# Altium schematic sheet Page-1.SchDoc
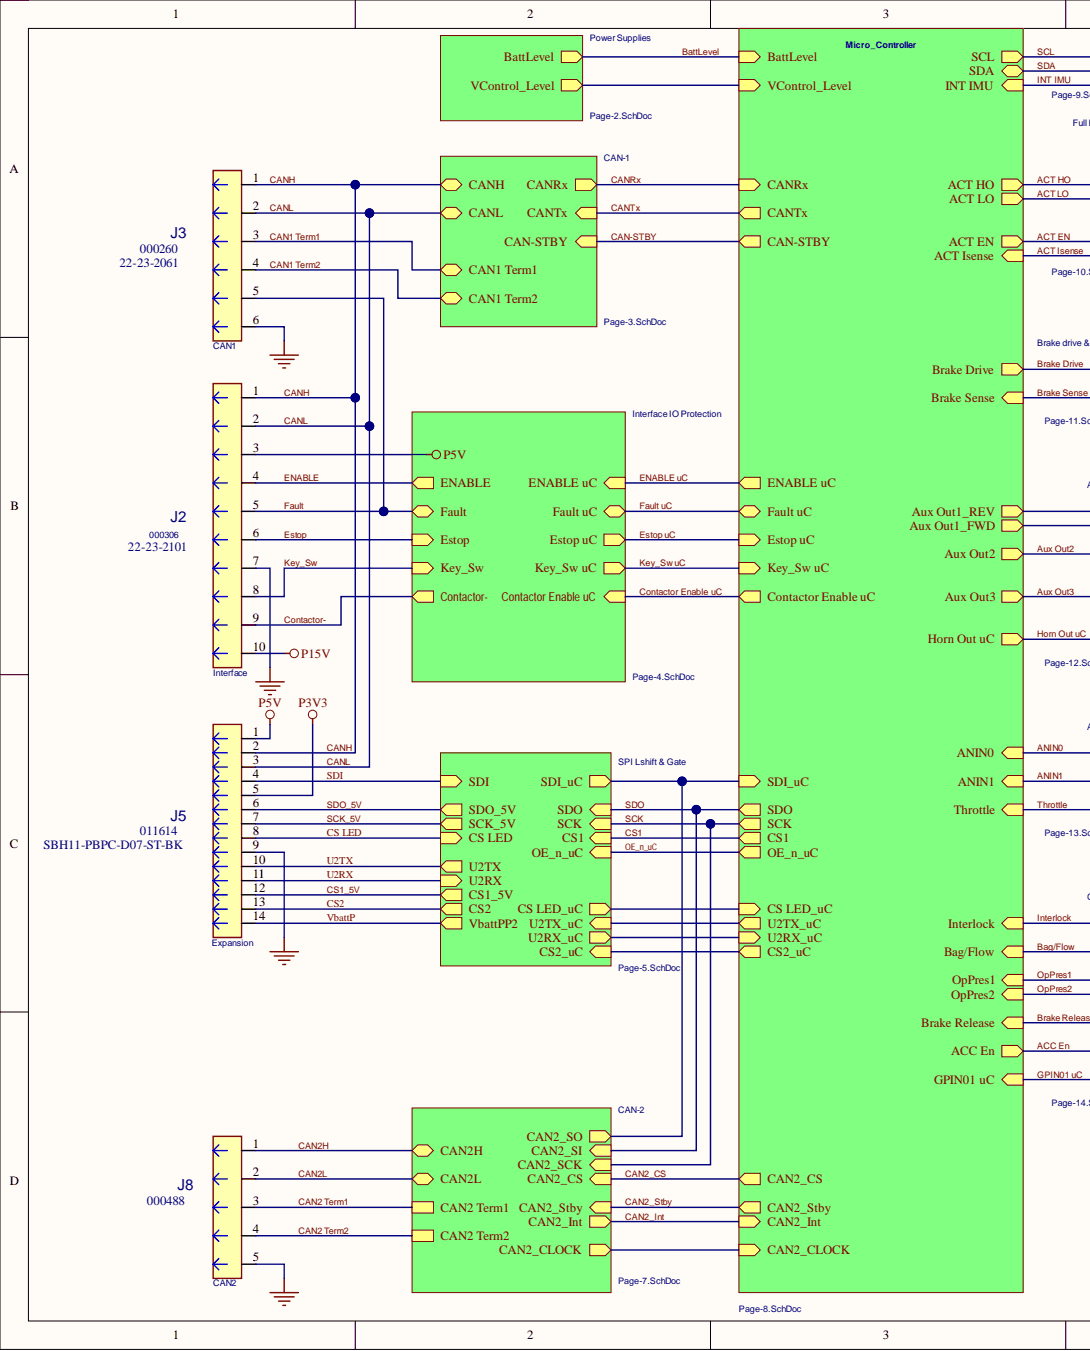
[diagram p0: Page-1.SchDoc, left]
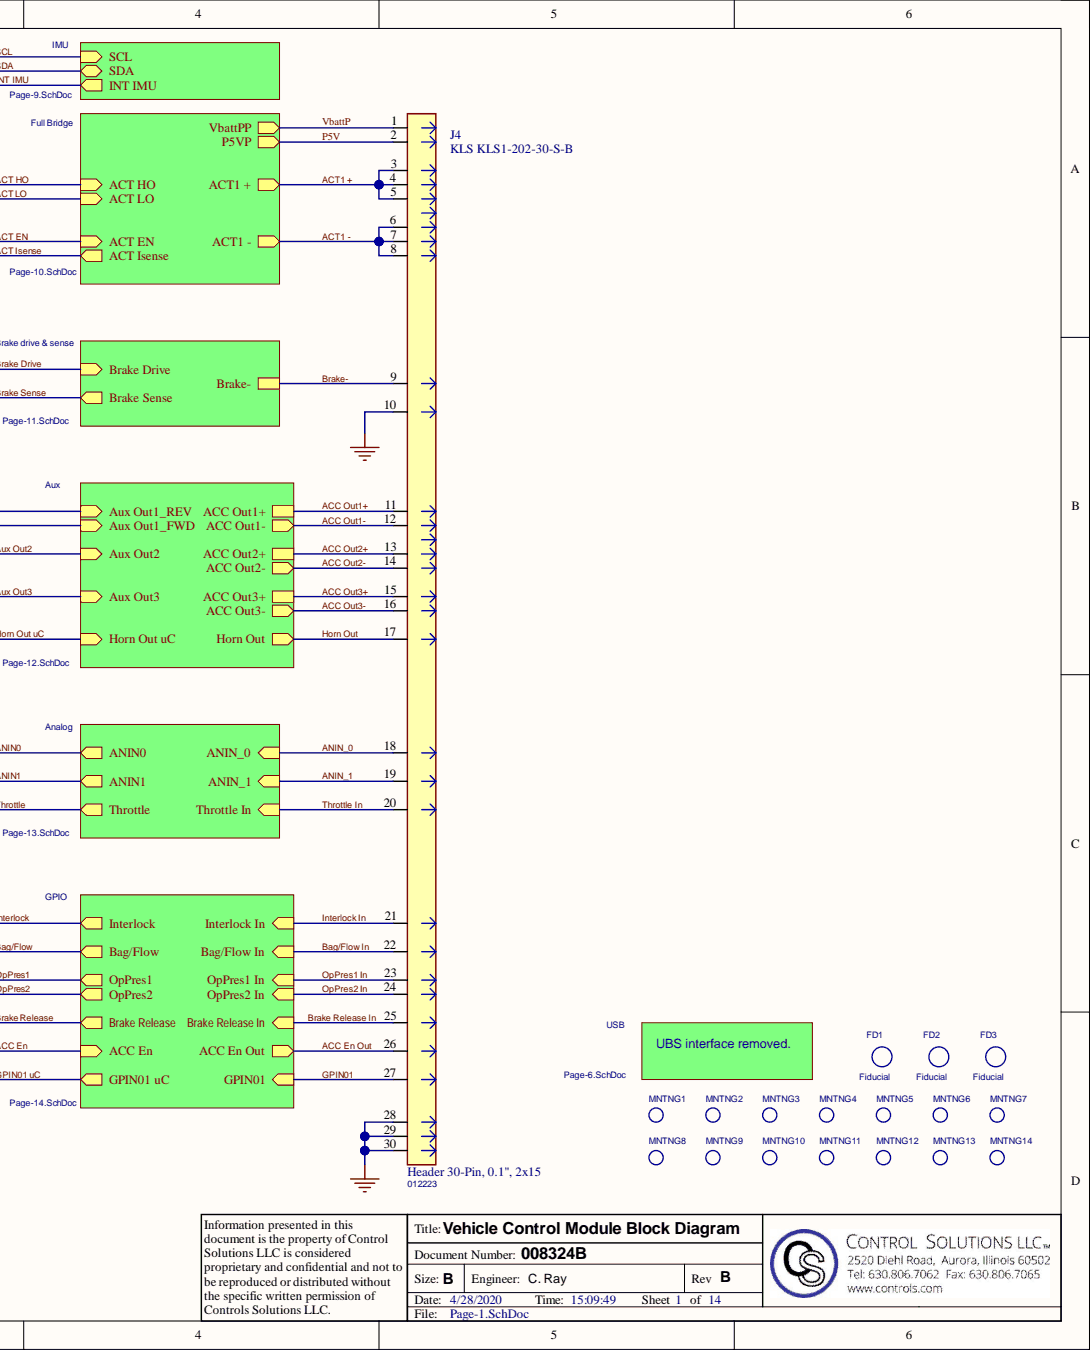
[diagram p1: Page-1.SchDoc, right]

## Parts
FD1  Fiducial  [CSISM/FIDUCIAL_TOP&BOTTOM]
FD2  Fiducial  [CSISM/FIDUCIAL_TOP&BOTTOM]
FD3  Fiducial  [CSISM/FIDUCIAL_TOP&BOTTOM]
J2  Interface  Mfr. P/N: 22-23-2101  [CSIHEAD10\100\LOCK_10-1_PIN1IND]
J3  CAN1  [CSIHEAD6\100\LOCK_6-1_PIN1IND]
J4  Header 30-Pin, 0.1", 2x15  Manufacturer: KLS  Part Number: 012223  [Straight 30 Pin TH Connector, 0.1", 2x15]
J5  Expansion  Distr. P/N: SBH11-PBPC-D07-ST-BK  [CS/HEADER/100/2X7_SHROUD_PIN1IND]
J8  CAN2  Part Number: 000488  [CSIHEAD5\100\LOCK_5-1_PIN1IND]
MNTNG1  M HOLE1_1  [MTHOLE_156]  (value not drawn)
MNTNG2  M HOLE1_1  [MTHOLE_156]  (value not drawn)
MNTNG3  M HOLE1_1  [MTHOLE_156]  (value not drawn)
MNTNG4  M HOLE1_1  [MTHOLE_156]  (value not drawn)
MNTNG5  M HOLE1_1  [MTHOLE_156]  (value not drawn)
MNTNG6  M HOLE1_1  [MTHOLE_156]  (value not drawn)
MNTNG7  M HOLE1_1  [MTHOLE_156]  (value not drawn)
MNTNG8  M HOLE1_1  [MTHOLE_156]  (value not drawn)
MNTNG9  M HOLE1_1  [MTHOLE_156]  (value not drawn)
MNTNG10  M HOLE1_1  [MTHOLE_156]  (value not drawn)
MNTNG11  M HOLE1_1  [MTHOLE_156]  (value not drawn)
MNTNG12  M HOLE1_1  [MTHOLE_156]  (value not drawn)
MNTNG13  M HOLE1_1  [MTHOLE_156]  (value not drawn)
MNTNG14  M HOLE1_1  [MTHOLE_156]  (value not drawn)

## Nets
GND: J2.7 J3.6 J4.10 J4.28 J4.29 J4.30 J5.9 J8.5
P3V3: J5.5
P5V: J2.3 J5.1
P15V: J2.10
ACC En Out: J4.26
ACC Out1+: J4.11
ACC Out1-: J4.12
ACC Out2+: J4.13
ACC Out2-: J4.14
ACC Out3+: J4.15
ACC Out3-: J4.16
ACT1 +: J4.3 J4.4 J4.5
ACT1 -: J4.6 J4.7 J4.8
ANIN_0: J4.18
ANIN_1: J4.19
Bag/Flow In: J4.22
Brake Release In: J4.25
Brake-: J4.9
CAN1 Term1: J3.3
CAN1 Term2: J3.4
CAN2 Term1: J8.3
CAN2 Term2: J8.4
CAN2H: J8.1
CAN2L: J8.2
CANH: J2.1 J3.1 J5.2
CANL: J2.2 J3.2 J5.3
Contactor-: J2.9
CS1_5V: J5.12
CS2: J5.13
CS LED: J5.8
ENABLE: J2.4
Estop: J2.6
Fault: J2.5 J3.5
GPIN01: J4.27
Horn Out: J4.17
Interlock In: J4.21
Key_Sw: J2.8
OpPres1 In: J4.23
OpPres2 In: J4.24
SCK_5V: J5.7
SDI: J5.4
SDO_5V: J5.6
Throttle In: J4.20
U2RX: J5.11
U2TX: J5.10
NetFD1_1: FD1.1
NetFD2_1: FD2.1
NetFD3_1: FD3.1
NetJ4_1: J4.1
NetJ4_2: J4.2
NetJ5_14: J5.14
NetMNTNG1_1: MNTNG1.1
NetMNTNG2_1: MNTNG2.1
NetMNTNG3_1: MNTNG3.1
NetMNTNG4_1: MNTNG4.1
NetMNTNG5_1: MNTNG5.1
NetMNTNG6_1: MNTNG6.1
NetMNTNG7_1: MNTNG7.1
NetMNTNG8_1: MNTNG8.1
NetMNTNG9_1: MNTNG9.1
NetMNTNG10_1: MNTNG10.1
NetMNTNG11_1: MNTNG11.1
NetMNTNG12_1: MNTNG12.1
NetMNTNG13_1: MNTNG13.1
NetMNTNG14_1: MNTNG14.1
ACC En:
ACT EN:
ACT HO:
ACT Isense:
ACT LO:
ANIN0:
ANIN1:
Aux Out1_FWD:
Aux Out1_REV:
Aux Out2:
Aux Out3:
Bag/Flow:
BattLevel:
Brake Drive:
Brake Release:
Brake Sense:
CAN2_CLOCK:
CAN2_CS:
CAN2_Int:
CAN2_SO:
CAN2_Stby:
CAN-STBY:
CANRx:
CANTx:
Contactor Enable uC:
CS1:
CS2_uC:
CS LED_uC:
ENABLE uC:
Estop uC:
Fault uC:
GPIN01 uC:
Horn Out uC:
INT IMU:
Interlock:
Key_Sw uC:
OE_n_uC:
OpPres1:
OpPres2:
SCK:
SCL:
SDA:
SDO:
Throttle:
U2RX_uC:
U2TX_uC:
VControl_Level:

## Library data of the parts
FD1 = Fiducial_1: COMPFIXED: YES; COMPGROUP: 0; COMPHEIGHT: 0.000; COMPKEY: NO; COMPLOC: [5050.000,225.000]; COMPSIDE: TOP; FPLIST: CSISM/FIDUCIAL; NC: 1; PSpiceOnly: False; Variant-1: Don't populate; COMPLOCKED: YES; Component Kind: Standard; COMPROT: 0; DECOUPLER: NO; DigiKey LargeQtyCost: 0; MEMORY: NO; Note1: Note1; Note2: Note2; Note3: Note3; Part Number: N/A; Status: N/A; TCR: N/A
FD2 = Fiducial_1: COMPFIXED: YES; COMPGROUP: 0; COMPHEIGHT: 0.000; COMPKEY: NO; COMPLOC: [450.000,225.000]; COMPSIDE: TOP; FPLIST: CSISM/FIDUCIAL_B,CSISM/FIDUCIAL; NC: 1; PSpiceOnly: False; Variant-1: Don't populate; COMPLOCKED: YES; Component Kind: Standard; COMPROT: 0; DECOUPLER: NO; DigiKey LargeQtyCost: 0; MEMORY: NO; Note1: Note1; Note2: Note2; Note3: Note3; Part Number: N/A; TCR: N/A
FD3 = Fiducial_1: Part Number: Not Pop.; COMPFIXED: YES; COMPGROUP: 0; COMPHEIGHT: 0.000; COMPKEY: NO; COMPLOC: [450.000,7875.000]; COMPSIDE: TOP; FPLIST: CSISM/FIDUCIAL_TOP&BOTTOM,CSISM/FIDUCIAL; NC: 1; PSpiceOnly: False; Variant-1: Don't populate; COMPLOCKED: YES; Component Kind: Standard; COMPROT: 0; DECOUPLER: NO; DigiKey LargeQtyCost: 0; MEMORY: NO; Note1: Note1; Note2: Note2; Note3: Note3; TCR: N/A
J2 = header 10: CONN HEADER VERT 10POS 2.54MM; Manufacturer: Molex; Part Number: 000306; COMPFIXED: YES; COMPGROUP: 0; COMPHEIGHT: 0.000; COMPKEY: NO; COMPLOC: [5250.000,1950.000]; COMPROT: 90; COMPSIDE: TOP; CURRENT: 4.0A; Digi 100 qty: 0.44760; Distr. P/N: WM4208-ND; FPLIST: CSIHEAD10\100\LOCK_10-1_PIN1IND_REV1,CSIHEAD10\100\LOCK_10-1,CSIHEAD10\100\LOCK_10-1_REV1,CSIHEAD10\100\LOCK_10-1_PIN1IND; Voltage: 500V; COMPLOCKED: YES; Component Kind: Standard; Datasheet: https://www.molex.com/pdm_docs/sd/022232101_sd.pdf; DECOUPLER: NO; DigiKey P/N, (CT): WM4208-ND; Flammability: 94V-0; MEMORY: NO; Octopart 1K qty: $0.245; RoHS: Compliant; Status: Active
J3 = 22-23-2061: CONN HEADER VERT 6POS 2.54MM; Manufacturer: Molex; Part Number: 000260; COMPFIXED: YES; COMPGROUP: 0; COMPHEIGHT: 0.000; COMPKEY: NO; COMPLOC: [5250.000,1500.000]; COMPROT: 90; COMPSIDE: TOP; Current: 2.5A; Digi 100 qty: 0.28180; Distr. P/N: WM4204-ND; FPLIST: CSIHEAD6\100\LOCK_6-1_PIN1IND,CSIHEAD5\100\LOCK_5-1,CSIHEAD6\100\LOCK_6-1,CSIHEAD6\100\LOCK_6-1_PIN1IND_REV2; Voltage: CSA 250, UL 600; COMPLOCKED: YES; Component Kind: Standard; Datasheet: http://www.molex.com/webdocs/datasheets/pdf/en-us/0022232061_PCB_HEADERS.pdf; DECOUPLER: NO; DigiKey P/N,(CT): WM4204-ND; MEMORY: NO; Mfr. P/N: 22-23-2061; Octopart 1K qty: $0.147; RoHS: Compliant; Status: Active
J4 = Header 30-Pin, 0.1", 2x15: Connector, Header 2x15 Male Pins .100 in Pitch Straight Header w/ Key; Mfr. P/N: KLS KLS1-202-30-S-B; Current: 1A; Voltage: DC 500V; Component Kind: Standard; Library Name: 008324A_Library.SchLib; RoHS: Compliant; Status: Active
J5 = CON14: Connector Header Vertical Through Hole 14 position 0.100" (2.54mm); Manufacturer: Sullins Connector Solutions; Part Number: 011614; COMPFIXED: NO; COMPGROUP: 0; COMPHEIGHT: 0.000; COMPLOC: [1190.000,275.000]; COMPSIDE: TOP; Current: 3A; Digi 100 qty: 0.28980; FPLIST: CS/HEADER_2MM_2X7_SHROUD_PIN1IND_REV1,CS/HEADER_2MM_2X7_SHROUD_PIN1IND,CS/HEADER_2MM_2X7_SHROUD; Voltage: <Voltage>; COMPKEY: NO; COMPLOCKED: NO; Component Kind: Standard; COMPROT: 0; Datasheet: https://s3.amazonaws.com/catalogspreads-pdf/PAGE122%20.100%20SBH11%20SERIES%20MALE%20BOX%20HDR%20ST%20RA%20SMT.pdf; DECOUPLER: NO; DigiKey P/N,(CT): S9170-ND; Flammability: UL94 V-0; MEMORY: NO; Mfr. P/N: SBH11-PBPC-D07-ST-BK; Octopart 1K qty: $0.210; RoHS: Compliant; Status: Active; Temperature: -40 C to 105 C
J8 = 22-23-2051 5-pin locking header: Connector Header Through Hole 5 position 0.100" (2.54mm); Manufacturer: Molex; COMPFIXED: YES; COMPGROUP: 0; COMPHEIGHT: 0.000; COMPKEY: NO; COMPLOC: [5250.000,750.000]; COMPROT: 90; COMPSIDE: TOP; Current: 4.0A; Digi 100 qty: 0.24570; Distr. P/N: WM4203-ND; Flamability: UL94 V-0; FPLIST: CSIHEAD5\100\LOCK_5-1_PIN1IND_REV1,CSIHEAD5\100\LOCK_5-1,CSIHEAD5\100\LOCK_5-1_REV1,CSIHEAD5\100\LOCK_5-1_PIN1IND; UL: UL File Number E29179; Voltage: 500 VAC / 600 VDC; COMPLOCKED: YES; Component Kind: Standard; Datasheet: UL94 V-0; DECOUPLER: NO; DigiKey P/N, (CT): WM4203-ND; MEMORY: NO; Mfr. P/N: 22-23-2051; Octopart 1K qty: $0.122; RoHS: Compliant; Status: Active
MNTNG1 = M HOLE1_1: COMPFIXED: YES; COMPGROUP: 0; COMPHEIGHT: 0.000; COMPKEY: NO; COMPLOC: [225.000,7875.000]; COMPSIDE: TOP; NC: 1; PSpiceOnly: False; COMPLOCKED: YES; Component Kind: Standard; COMPROT: 0; DECOUPLER: NO; DigiKey LargeQtyCost: 0; FPLIST: MTHOLE_156; MEMORY: NO; Note1: Note1; Note2: Note2; Note3: Note3; Part Number: N/A; TCR: N/A
MNTNG2 = M HOLE1_1: COMPFIXED: YES; COMPGROUP: 0; COMPHEIGHT: 0.000; COMPKEY: NO; COMPLOC: [2750.000,7875.000]; COMPSIDE: TOP; NC: 1; PSpiceOnly: False; COMPLOCKED: YES; Component Kind: Standard; COMPROT: 0; DECOUPLER: NO; DigiKey LargeQtyCost: 0; FPLIST: MTHOLE_156; MEMORY: NO; Note1: Note1; Note2: Note2; Note3: Note3; Part Number: N/A; TCR: N/A
MNTNG3 = M HOLE1_1: COMPFIXED: YES; COMPGROUP: 0; COMPHEIGHT: 0.000; COMPKEY: NO; COMPLOC: [5275.000,7875.000]; COMPSIDE: TOP; NC: 1; PSpiceOnly: False; COMPLOCKED: YES; Component Kind: Standard; COMPROT: 0; DECOUPLER: NO; DigiKey LargeQtyCost: 0; FPLIST: MTHOLE_156; MEMORY: NO; Note1: Note1; Note2: Note2; Note3: Note3; Part Number: N/A; TCR: N/A
MNTNG4 = M HOLE1_1: COMPFIXED: YES; COMPGROUP: 0; COMPHEIGHT: 0.000; COMPKEY: NO; COMPLOC: [225.000,4050.000]; COMPSIDE: TOP; NC: 1; PSpiceOnly: False; COMPLOCKED: YES; Component Kind: Standard; COMPROT: 0; DECOUPLER: NO; DigiKey LargeQtyCost: 0; FPLIST: MTHOLE_156; MEMORY: NO; Note1: Note1; Note2: Note2; Note3: Note3; Part Number: N/A; TCR: N/A
MNTNG5 = M HOLE1_1: COMPFIXED: YES; COMPGROUP: 0; COMPHEIGHT: 0.000; COMPKEY: NO; COMPLOC: [5275.000,4050.000]; COMPSIDE: TOP; NC: 1; PSpiceOnly: False; COMPLOCKED: YES; Component Kind: Standard; COMPROT: 0; DECOUPLER: NO; DigiKey LargeQtyCost: 0; FPLIST: MTHOLE_156; MEMORY: NO; Note1: Note1; Note2: Note2; Note3: Note3; Part Number: N/A; TCR: N/A
MNTNG6 = M HOLE1_1: COMPFIXED: YES; COMPGROUP: 0; COMPHEIGHT: 0.000; COMPKEY: NO; COMPLOC: [225.000,225.000]; COMPSIDE: TOP; NC: 1; PSpiceOnly: False; COMPLOCKED: YES; Component Kind: Standard; COMPROT: 0; DECOUPLER: NO; DigiKey LargeQtyCost: 0; FPLIST: MTHOLE_156; MEMORY: NO; Note1: Note1; Note2: Note2; Note3: Note3; Part Number: N/A; TCR: N/A
MNTNG7 = M HOLE1_1: COMPFIXED: YES; COMPGROUP: 0; COMPHEIGHT: 0.000; COMPKEY: NO; COMPLOC: [2750.000,225.000]; COMPSIDE: TOP; NC: 1; PSpiceOnly: False; COMPLOCKED: YES; Component Kind: Standard; COMPROT: 0; DECOUPLER: NO; DigiKey LargeQtyCost: 0; FPLIST: MTHOLE_156; MEMORY: NO; Note1: Note1; Note2: Note2; Note3: Note3; Part Number: N/A; TCR: N/A
MNTNG8 = M HOLE1_1: COMPFIXED: YES; COMPGROUP: 0; COMPHEIGHT: 0.000; COMPKEY: NO; COMPLOC: [5275.000,225.000]; COMPSIDE: TOP; NC: 1; PSpiceOnly: False; COMPLOCKED: YES; Component Kind: Standard; COMPROT: 0; DECOUPLER: NO; DigiKey LargeQtyCost: 0; FPLIST: MTHOLE_156; MEMORY: NO; Note1: Note1; Note2: Note2; Note3: Note3; Part Number: N/A; TCR: N/A
MNTNG9 = M HOLE1_1: COMPFIXED: YES; COMPGROUP: 0; COMPHEIGHT: 0.000; COMPKEY: NO; COMPLOC: [225.000,3750.000]; COMPSIDE: TOP; NC: 1; PSpiceOnly: False; COMPLOCKED: YES; Component Kind: Standard; COMPROT: 0; DECOUPLER: NO; DigiKey LargeQtyCost: 0; FPLIST: MTHOLE_156; MEMORY: NO; Note1: Note1; Note2: Note2; Note3: Note3; Part Number: N/A; TCR: N/A
MNTNG10 = M HOLE1_1: COMPFIXED: YES; COMPGROUP: 0; COMPHEIGHT: 0.000; COMPKEY: NO; COMPLOC: [2750.000,3750.000]; COMPSIDE: TOP; FPLIST: MTHOLE_156_REV1,MTHOLE_156; NC: 1; PSpiceOnly: False; COMPLOCKED: YES; Component Kind: Standard; COMPROT: 0; DECOUPLER: NO; DigiKey LargeQtyCost: 0; MEMORY: NO; Note1: Note1; Note2: Note2; Note3: Note3; Part Number: N/A; TCR: N/A
MNTNG11 = M HOLE1_1: COMPFIXED: NO; COMPGROUP: 0; COMPHEIGHT: 0.000; COMPLOC: [225.000,600.000]; COMPSIDE: TOP; NC: 1; PSpiceOnly: False; COMPKEY: NO; COMPLOCKED: NO; Component Kind: Standard; COMPROT: 0; DECOUPLER: NO; DigiKey LargeQtyCost: 0; FPLIST: MTHOLE_156; MEMORY: NO; Note1: Note1; Note2: Note2; Note3: Note3; Part Number: N/A; TCR: N/A
MNTNG12 = M HOLE1_1: COMPFIXED: NO; COMPGROUP: 0; COMPHEIGHT: 0.000; COMPLOC: [2750.000,600.000]; COMPSIDE: TOP; NC: 1; PSpiceOnly: False; COMPKEY: NO; COMPLOCKED: NO; Component Kind: Standard; COMPROT: 0; DECOUPLER: NO; DigiKey LargeQtyCost: 0; FPLIST: MTHOLE_156; MEMORY: NO; Note1: Note1; Note2: Note2; Note3: Note3; Part Number: N/A; TCR: N/A
MNTNG13 = M HOLE1_1: COMPFIXED: YES; COMPGROUP: 0; COMPHEIGHT: 0.000; COMPKEY: NO; COMPLOC: [2750.000,1650.000]; COMPSIDE: TOP; NC: 1; PSpiceOnly: False; COMPLOCKED: YES; Component Kind: Standard; COMPROT: 0; DECOUPLER: NO; DigiKey LargeQtyCost: 0; MEMORY: NO; Note1: Note1; Note2: Note2; Note3: Note3; Part Number: N/A; TCR: N/A
MNTNG14 = M HOLE1_1: COMPFIXED: YES; COMPGROUP: 0; COMPHEIGHT: 0.000; COMPKEY: NO; COMPLOC: [225.000,1650.000]; COMPSIDE: TOP; FPLIST: MTHOLE_156-2; NC: 1; PSpiceOnly: False; COMPLOCKED: YES; Component Kind: Standard; COMPROT: 0; DECOUPLER: NO; DigiKey LargeQtyCost: 0; MEMORY: NO; Note1: Note1; Note2: Note2; Note3: Note3; Part Number: N/A; TCR: N/A
